# Altium schematic sheet BlinkBoard_micro_v1.SchDoc
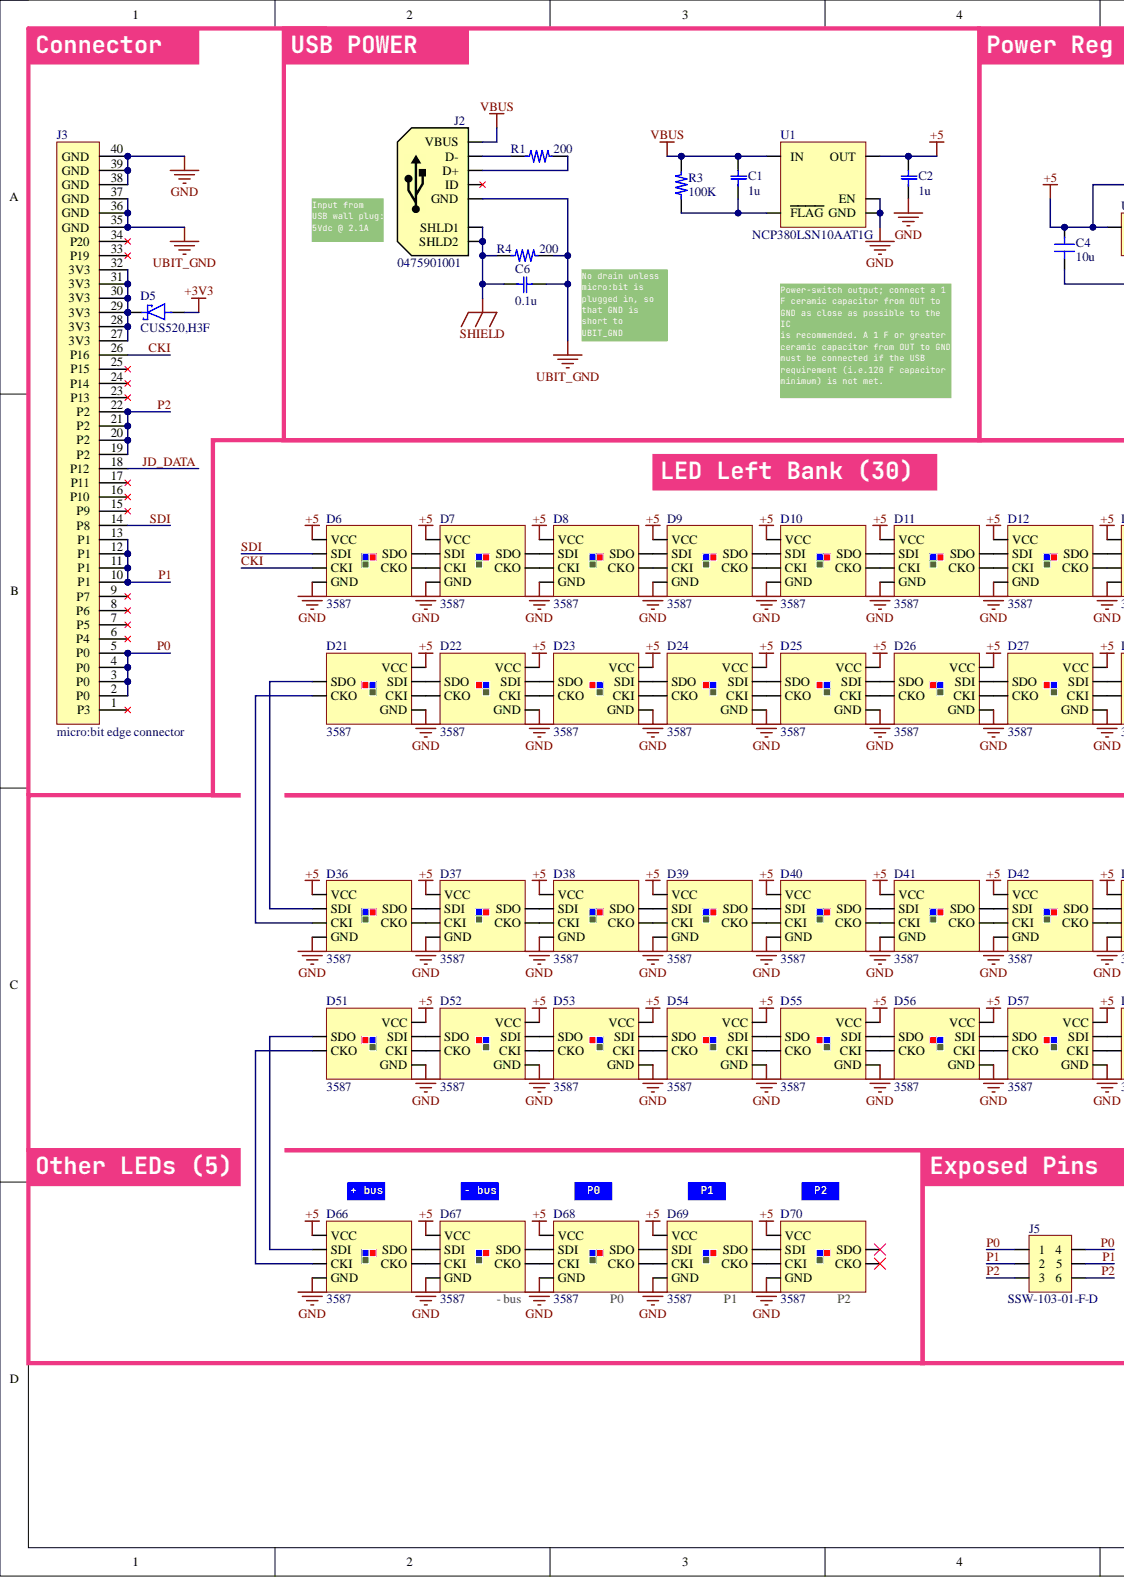
[diagram p0: BlinkBoard_micro_v1.SchDoc, left]
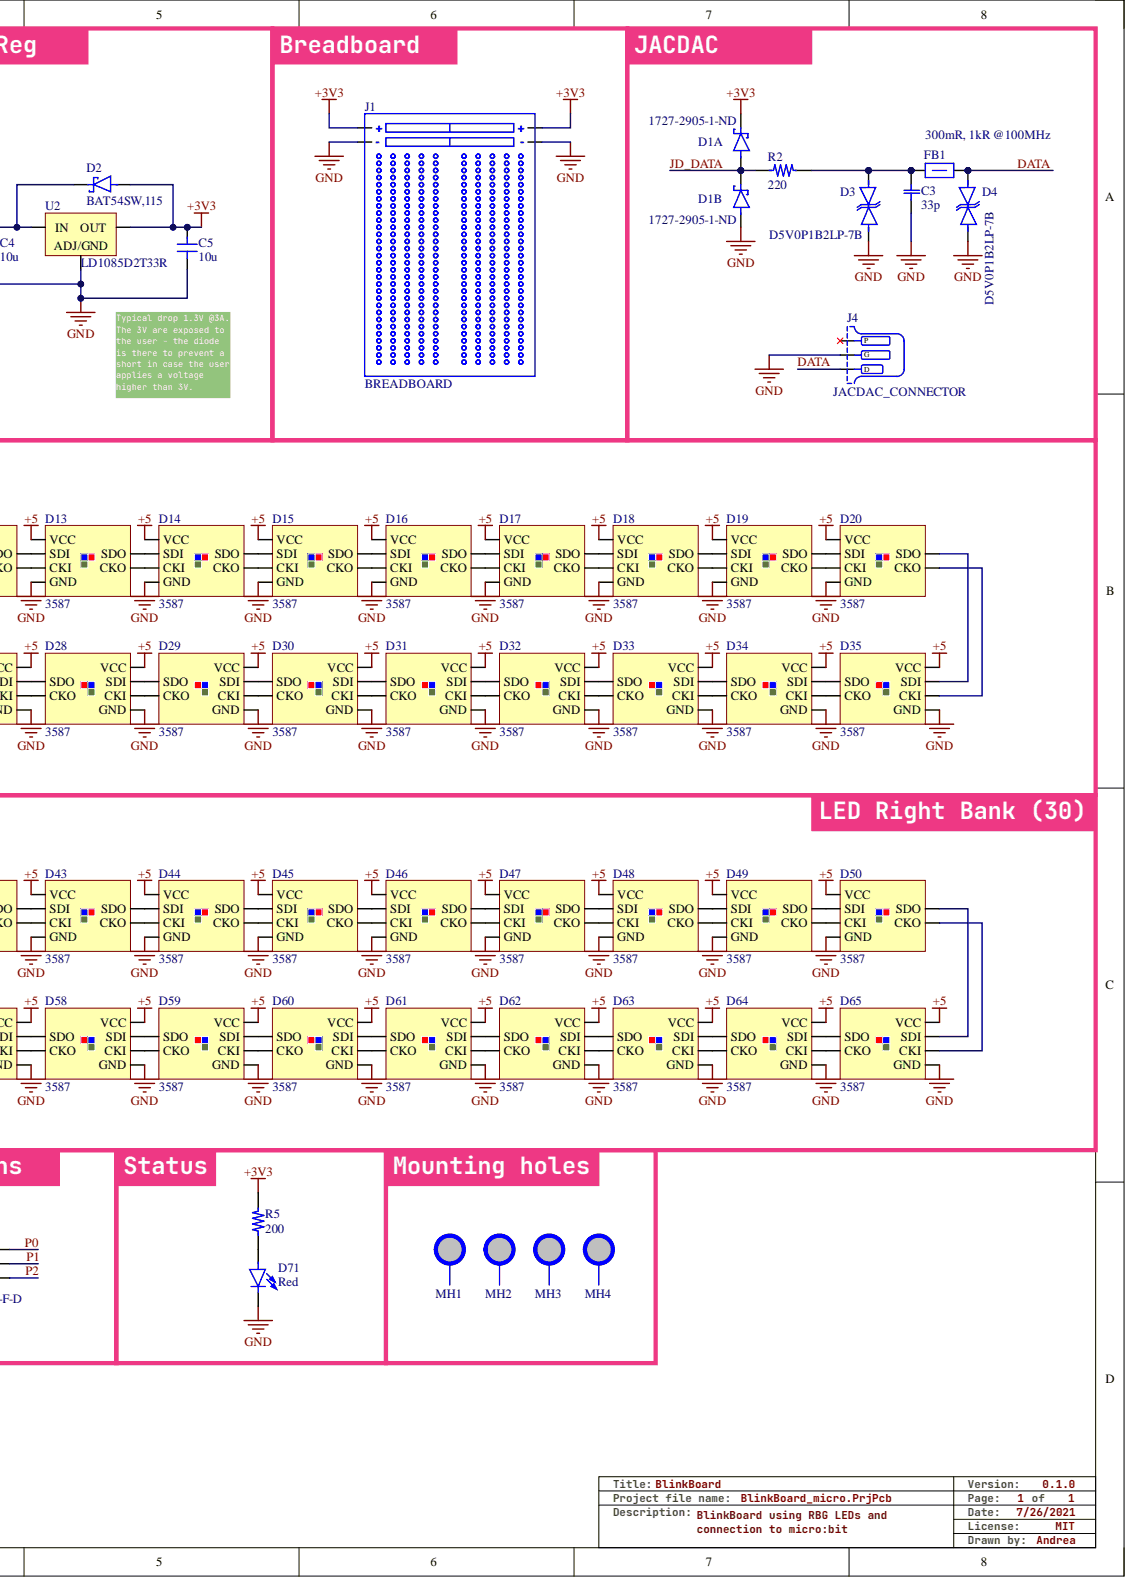
[diagram p1: BlinkBoard_micro_v1.SchDoc, right]

## Parts
C1  1u
C2  1u
C3  33p
C4  10u  [C0805]
C5  10u  [C0805]
C6  0.1u  [C0603]
D1  1727-2905-1-ND  (parts A,B)
D2  BAT54SW,115
D3  D5V0P1B2LP-7B
D4  D5V0P1B2LP-7B
D5  CUS520,H3F
D6  3587  [APA102-2020]
D7  3587  [APA102-2020]
D8  3587  [APA102-2020]
D9  3587  [APA102-2020]
D10  3587  [APA102-2020]
D11  3587  [APA102-2020]
D12  3587  [APA102-2020]
D13  3587  [APA102-2020]
D14  3587  [APA102-2020]
D15  3587  [APA102-2020]
D16  3587  [APA102-2020]
D17  3587  [APA102-2020]
D18  3587  [APA102-2020]
D19  3587  [APA102-2020]
D20  3587  [APA102-2020]
D21  3587  [APA102-2020]
D22  3587  [APA102-2020]
D23  3587  [APA102-2020]
D24  3587  [APA102-2020]
D25  3587  [APA102-2020]
D26  3587  [APA102-2020]
D27  3587  [APA102-2020]
D28  3587  [APA102-2020]
D29  3587  [APA102-2020]
D30  3587  [APA102-2020]
D31  3587  [APA102-2020]
D32  3587  [APA102-2020]
D33  3587  [APA102-2020]
D34  3587  [APA102-2020]
D35  3587  [APA102-2020]
D36  3587  [APA102-2020]
D37  3587  [APA102-2020]
D38  3587  [APA102-2020]
D39  3587  [APA102-2020]
D40  3587  [APA102-2020]
D41  3587  [APA102-2020]
D42  3587  [APA102-2020]
D43  3587  [APA102-2020]
D44  3587  [APA102-2020]
D45  3587  [APA102-2020]
D46  3587  [APA102-2020]
D47  3587  [APA102-2020]
D48  3587  [APA102-2020]
D49  3587  [APA102-2020]
D50  3587  [APA102-2020]
D51  3587  [APA102-2020]
D52  3587  [APA102-2020]
D53  3587  [APA102-2020]
D54  3587  [APA102-2020]
D55  3587  [APA102-2020]
D56  3587  [APA102-2020]
D57  3587  [APA102-2020]
D58  3587  [APA102-2020]
D59  3587  [APA102-2020]
D60  3587  [APA102-2020]
D61  3587  [APA102-2020]
D62  3587  [APA102-2020]
D63  3587  [APA102-2020]
D64  3587  [APA102-2020]
D65  3587  [APA102-2020]
D66  3587  [APA102-2020]
D67  3587  [APA102-2020]
D68  3587  [APA102-2020]
D69  3587  [APA102-2020]
D70  3587  [APA102-2020]
D71  Red
FB1  300mR, 1kR @100MHz  Impedance: 1kR
J1  BREADBOARD
J2  0475901001  Connector Type: USB  [USB_MICRO]
J3  micro:bit edge connector
J4  JACDAC_CONNECTOR
J5  SSW-103-01-F-D
MH1  MH 3.2mm NP  [MH3.2MM]  (value not drawn)  (no BOM)
MH2  MH 3.2mm NP  [MH3.2MM]  (value not drawn)  (no BOM)
MH3  MH 3.2mm NP  [MH3.2MM]  (value not drawn)  (no BOM)
MH4  MH 3.2mm NP  [MH3.2MM]  (value not drawn)  (no BOM)
R1  200  [RES0805]
R2  220
R3  100K
R4  200  [RES0805]
R5  200  [RES0805]
U1  NCP380LSN10AAT1G
U2  LD1085D2T33R  [D2PAK]

## Nets
+3V3: C5.2 D1.2 D2.2 D5.2 J1.1(+) J1.3(+) R5.2 U2.4
+5: C2.2 C4.2 D2.1 D6.4(VCC) D7.4(VCC) D8.4(VCC) D9.4(VCC) D10.4(VCC) D11.4(VCC) D12.4(VCC) D13.4(VCC) D14.4(VCC) D15.4(VCC) D16.4(VCC) D17.4(VCC) D18.4(VCC) D19.4(VCC) D20.4(VCC) D21.4(VCC) D22.4(VCC) D23.4(VCC) D24.4(VCC) D25.4(VCC) D26.4(VCC) D27.4(VCC) D28.4(VCC) D29.4(VCC) D30.4(VCC) D31.4(VCC) D32.4(VCC) D33.4(VCC) D34.4(VCC) D35.4(VCC) D36.4(VCC) D37.4(VCC) D38.4(VCC) D39.4(VCC) D40.4(VCC) D41.4(VCC) D42.4(VCC) D43.4(VCC) D44.4(VCC) D45.4(VCC) D46.4(VCC) D47.4(VCC) D48.4(VCC) D49.4(VCC) D50.4(VCC) D51.4(VCC) D52.4(VCC) D53.4(VCC) D54.4(VCC) D55.4(VCC) D56.4(VCC) D57.4(VCC) D58.4(VCC) D59.4(VCC) D60.4(VCC) D61.4(VCC) D62.4(VCC) D63.4(VCC) D64.4(VCC) D65.4(VCC) D66.4(VCC) D67.4(VCC) D68.4(VCC) D69.4(VCC) D70.4(VCC) U1.1(OUT) U2.3
GND: C2.1 C3.1 C4.1 C5.1 D1.1 D3.1 D4.1 D6.3(GND) D7.3(GND) D8.3(GND) D9.3(GND) D10.3(GND) D11.3(GND) D12.3(GND) D13.3(GND) D14.3(GND) D15.3(GND) D16.3(GND) D17.3(GND) D18.3(GND) D19.3(GND) D20.3(GND) D21.3(GND) D22.3(GND) D23.3(GND) D24.3(GND) D25.3(GND) D26.3(GND) D27.3(GND) D28.3(GND) D29.3(GND) D30.3(GND) D31.3(GND) D32.3(GND) D33.3(GND) D34.3(GND) D35.3(GND) D36.3(GND) D37.3(GND) D38.3(GND) D39.3(GND) D40.3(GND) D41.3(GND) D42.3(GND) D43.3(GND) D44.3(GND) D45.3(GND) D46.3(GND) D47.3(GND) D48.3(GND) D49.3(GND) D50.3(GND) D51.3(GND) D52.3(GND) D53.3(GND) D54.3(GND) D55.3(GND) D56.3(GND) D57.3(GND) D58.3(GND) D59.3(GND) D60.3(GND) D61.3(GND) D62.3(GND) D63.3(GND) D64.3(GND) D65.3(GND) D66.3(GND) D67.3(GND) D68.3(GND) D69.3(GND) D70.3(GND) D71.1 J1.2(-) J1.4(-) J3.38(GND) J3.39(GND) J3.40(GND) J4.2 U1.2(GND) U1.4(EN) U2.1
SHIELD: C6.1 J2.6(SHLD1) J2.7(SHLD2) R4.1
UBIT_GND: C6.2 J2.5(GND) J3.35(GND) J3.36(GND) J3.37(GND) R4.2
VBUS: C1.2 J2.1(VBUS) R3.2 U1.5(IN)
CKI: D6.2(CKI) J3.26(P16)
DATA: D4.2 FB1.2 J4.3
JD_DATA: D1.3 J3.18(P12) R2.1
P0: J3.2(P0) J3.3(P0) J3.4(P0) J3.5(P0) J5.1 J5.6
P1: J3.10(P1) J3.11(P1) J3.12(P1) J3.13(P1) J5.2 J5.5
P2: J3.19(P2) J3.20(P2) J3.21(P2) J3.22(P2) J5.3 J5.4
SDI: D6.1(SDI) J3.14(P8)
NetC1_1: C1.1 R3.1 U1.3(FLAG)
NetC3_2: C3.2 D3.2 FB1.1 R2.2
NetD5_1: D5.1 J3.27(3V3) J3.28(3V3) J3.29(3V3) J3.30(3V3) J3.31(3V3) J3.32(3V3)
NetD6_5: D6.5(CKO) D7.2(CKI)
NetD6_6: D6.6(SDO) D7.1(SDI)
NetD7_5: D7.5(CKO) D8.2(CKI)
NetD7_6: D7.6(SDO) D8.1(SDI)
NetD8_5: D8.5(CKO) D9.2(CKI)
NetD8_6: D8.6(SDO) D9.1(SDI)
NetD9_5: D9.5(CKO) D10.2(CKI)
NetD9_6: D9.6(SDO) D10.1(SDI)
NetD10_5: D10.5(CKO) D11.2(CKI)
NetD10_6: D10.6(SDO) D11.1(SDI)
NetD11_5: D11.5(CKO) D12.2(CKI)
NetD11_6: D11.6(SDO) D12.1(SDI)
NetD12_5: D12.5(CKO) D13.2(CKI)
NetD12_6: D12.6(SDO) D13.1(SDI)
NetD13_5: D13.5(CKO) D14.2(CKI)
NetD13_6: D13.6(SDO) D14.1(SDI)
NetD14_5: D14.5(CKO) D15.2(CKI)
NetD14_6: D14.6(SDO) D15.1(SDI)
NetD15_5: D15.5(CKO) D16.2(CKI)
NetD15_6: D15.6(SDO) D16.1(SDI)
NetD16_5: D16.5(CKO) D17.2(CKI)
NetD16_6: D16.6(SDO) D17.1(SDI)
NetD17_5: D17.5(CKO) D18.2(CKI)
NetD17_6: D17.6(SDO) D18.1(SDI)
NetD18_5: D18.5(CKO) D19.2(CKI)
NetD18_6: D18.6(SDO) D19.1(SDI)
NetD19_5: D19.5(CKO) D20.2(CKI)
NetD19_6: D19.6(SDO) D20.1(SDI)
NetD20_5: D20.5(CKO) D35.2(CKI)
NetD20_6: D20.6(SDO) D35.1(SDI)
NetD21_1: D21.1(SDI) D22.6(SDO)
NetD21_2: D21.2(CKI) D22.5(CKO)
NetD21_5: D21.5(CKO) D36.2(CKI)
NetD21_6: D21.6(SDO) D36.1(SDI)
NetD22_1: D22.1(SDI) D23.6(SDO)
NetD22_2: D22.2(CKI) D23.5(CKO)
NetD23_1: D23.1(SDI) D24.6(SDO)
NetD23_2: D23.2(CKI) D24.5(CKO)
NetD24_1: D24.1(SDI) D25.6(SDO)
NetD24_2: D24.2(CKI) D25.5(CKO)
NetD25_1: D25.1(SDI) D26.6(SDO)
NetD25_2: D25.2(CKI) D26.5(CKO)
NetD26_1: D26.1(SDI) D27.6(SDO)
NetD26_2: D26.2(CKI) D27.5(CKO)
NetD27_1: D27.1(SDI) D28.6(SDO)
NetD27_2: D27.2(CKI) D28.5(CKO)
NetD28_1: D28.1(SDI) D29.6(SDO)
NetD28_2: D28.2(CKI) D29.5(CKO)
NetD29_1: D29.1(SDI) D30.6(SDO)
NetD29_2: D29.2(CKI) D30.5(CKO)
NetD30_1: D30.1(SDI) D31.6(SDO)
NetD30_2: D30.2(CKI) D31.5(CKO)
NetD31_1: D31.1(SDI) D32.6(SDO)
NetD31_2: D31.2(CKI) D32.5(CKO)
NetD32_1: D32.1(SDI) D33.6(SDO)
NetD32_2: D32.2(CKI) D33.5(CKO)
NetD33_1: D33.1(SDI) D34.6(SDO)
NetD33_2: D33.2(CKI) D34.5(CKO)
NetD34_1: D34.1(SDI) D35.6(SDO)
NetD34_2: D34.2(CKI) D35.5(CKO)
NetD36_5: D36.5(CKO) D37.2(CKI)
NetD36_6: D36.6(SDO) D37.1(SDI)
NetD37_5: D37.5(CKO) D38.2(CKI)
NetD37_6: D37.6(SDO) D38.1(SDI)
NetD38_5: D38.5(CKO) D39.2(CKI)
NetD38_6: D38.6(SDO) D39.1(SDI)
NetD39_5: D39.5(CKO) D40.2(CKI)
NetD39_6: D39.6(SDO) D40.1(SDI)
NetD40_5: D40.5(CKO) D41.2(CKI)
NetD40_6: D40.6(SDO) D41.1(SDI)
NetD41_5: D41.5(CKO) D42.2(CKI)
NetD41_6: D41.6(SDO) D42.1(SDI)
NetD42_5: D42.5(CKO) D43.2(CKI)
NetD42_6: D42.6(SDO) D43.1(SDI)
NetD43_5: D43.5(CKO) D44.2(CKI)
NetD43_6: D43.6(SDO) D44.1(SDI)
NetD44_5: D44.5(CKO) D45.2(CKI)
NetD44_6: D44.6(SDO) D45.1(SDI)
NetD45_5: D45.5(CKO) D46.2(CKI)
NetD45_6: D45.6(SDO) D46.1(SDI)
NetD46_5: D46.5(CKO) D47.2(CKI)
NetD46_6: D46.6(SDO) D47.1(SDI)
NetD47_5: D47.5(CKO) D48.2(CKI)
NetD47_6: D47.6(SDO) D48.1(SDI)
NetD48_5: D48.5(CKO) D49.2(CKI)
NetD48_6: D48.6(SDO) D49.1(SDI)
NetD49_5: D49.5(CKO) D50.2(CKI)
NetD49_6: D49.6(SDO) D50.1(SDI)
NetD50_5: D50.5(CKO) D65.2(CKI)
NetD50_6: D50.6(SDO) D65.1(SDI)
NetD51_1: D51.1(SDI) D52.6(SDO)
NetD51_2: D51.2(CKI) D52.5(CKO)
NetD51_5: D51.5(CKO) D66.2(CKI)
NetD51_6: D51.6(SDO) D66.1(SDI)
NetD52_1: D52.1(SDI) D53.6(SDO)
NetD52_2: D52.2(CKI) D53.5(CKO)
NetD53_1: D53.1(SDI) D54.6(SDO)
NetD53_2: D53.2(CKI) D54.5(CKO)
NetD54_1: D54.1(SDI) D55.6(SDO)
NetD54_2: D54.2(CKI) D55.5(CKO)
NetD55_1: D55.1(SDI) D56.6(SDO)
NetD55_2: D55.2(CKI) D56.5(CKO)
NetD56_1: D56.1(SDI) D57.6(SDO)
NetD56_2: D56.2(CKI) D57.5(CKO)
NetD57_1: D57.1(SDI) D58.6(SDO)
NetD57_2: D57.2(CKI) D58.5(CKO)
NetD58_1: D58.1(SDI) D59.6(SDO)
NetD58_2: D58.2(CKI) D59.5(CKO)
NetD59_1: D59.1(SDI) D60.6(SDO)
NetD59_2: D59.2(CKI) D60.5(CKO)
NetD60_1: D60.1(SDI) D61.6(SDO)
NetD60_2: D60.2(CKI) D61.5(CKO)
NetD61_1: D61.1(SDI) D62.6(SDO)
NetD61_2: D61.2(CKI) D62.5(CKO)
NetD62_1: D62.1(SDI) D63.6(SDO)
NetD62_2: D62.2(CKI) D63.5(CKO)
NetD63_1: D63.1(SDI) D64.6(SDO)
NetD63_2: D63.2(CKI) D64.5(CKO)
NetD64_1: D64.1(SDI) D65.6(SDO)
NetD64_2: D64.2(CKI) D65.5(CKO)
NetD66_5: D66.5(CKO) D67.2(CKI)
NetD66_6: D66.6(SDO) D67.1(SDI)
NetD67_5: D67.5(CKO) D68.2(CKI)
NetD67_6: D67.6(SDO) D68.1(SDI)
NetD68_5: D68.5(CKO) D69.2(CKI)
NetD68_6: D68.6(SDO) D69.1(SDI)
NetD69_5: D69.5(CKO) D70.2(CKI)
NetD69_6: D69.6(SDO) D70.1(SDI)
NetD71_2: D71.2 R5.1
NetJ2_2: J2.2(D-) R1.1
NetJ2_3: J2.3(D+) R1.2

Not connected: D70 5(CKO) 6(SDO); J2 4(ID); J3 1(P3) 6(P4) 7(P5) 8(P6) 9(P7) 15(P9) 16(P10) 17(P11) 23(P13) 24(P14) 25(P15) 33(P19) 34(P20); J4 1

## Library data of the parts
C1 C2 = C0805_1u: Surface Mount Ceramic Multilayer Capacitor 1uF -20% to 80% Y5V 25V 0805 Paper T/R; Manufacturer: Yageo; Manufacturer Part Number: CC0805ZRY5V8BB105; Capacitance: 1uF; Case Code (Imperial): 0805; Case Code (Metric): 2012; Case/Package: 0805; Category: Ceramic Capacitors; Component Kind: Standard; ComponentLink1Description: https://datasheet.ciiva.com/144/mlccorderingcode-144703.pdf?src-supplier=Digi-Key; ComponentLink1URL: https://datasheet.ciiva.com/144/mlccorderingcode-144703.pdf?src-supplier=Digi-Key; ComponentLink2Description: https://datasheet.ciiva.com/26863/getdatasheetpartid-419810-26863011.pdf?src-supplier=Verical; ComponentLink2URL: https://datasheet.ciiva.com/26863/getdatasheetpartid-419810-26863011.pdf?src-supplier=Verical; ComponentLink3Description: https://datasheet.ciiva.com/144/mlccorderingcode-144704.pdf?src-supplier=Digi-Key; ComponentLink3URL: https://datasheet.ciiva.com/144/mlccorderingcode-144704.pdf?src-supplier=Digi-Key; ComponentLink4Description: https://datasheet.ciiva.com/144/mlccorderingcode-144705.pdf?src-supplier=Digi-Key; ComponentLink4URL: https://datasheet.ciiva.com/144/mlccorderingcode-144705.pdf?src-supplier=Digi-Key; ComponentLink5Description: https://datasheet.ciiva.com/13208/upy-gp-np0-16v-to-50v-8-13208251.pdf?src-supplier=Mouser; ComponentLink5URL: https://datasheet.ciiva.com/13208/upy-gp-np0-16v-to-50v-8-13208251.pdf?src-supplier=Mouser; ComponentLink6Description: https://datasheet.ciiva.com/28450/1132289-28450860.pdf?src-supplier=Element14; ComponentLink6URL: https://datasheet.ciiva.com/28450/1132289-28450860.pdf?src-supplier=Element14; Depth: 1.25mm; Dielectric: Y5V; Halogen Free: Halogen Free; Height: 0.85mm; Lead Free: Lead Free; Length: 2mm; Max Operating Temperature: 85°C; Min Operating Temperature: -30°C; Mount: Surface Mount; Package Quantity: 1; Packaging: Tape and Reel; Pricing 1: 1=0.14000, 10=0.10200, 50=0.05820, 100=0.04820, 500=0.03378, 1000=0.02796 (USD); Radiation Hardening: No; REACH SVHC: No SVHC; Resistor Type: Ceramic; RoHS: Yes; RoHS Compliant: Yes; Series: CC; Stock 1: 285953; Supplier 1: Digi-Key; Supplier Part Number 1: 311-1358-1-ND; Termination: SMD/SMT; Thickness: 0.033inch; Tolerance: 80%; Voltage Rating: 25V; Voltage Rating (DC): 25V; Weight: 0.000194oz; Width: 0.049inch; Library Name: BlinkBoard_micro.SchLib
C3 = C0805_33p: Cap Ceramic 33pF 50V C0G 5% SMD 0805 125C Paper T/R; Manufacturer: Yageo; Manufacturer Part Number: CC0805JRNPO9BN330; Capacitance: 33pF; Case Code (Imperial): 0805; Case Code (Metric): 2012; Case/Package: 0805; Category: Ceramic Capacitors; Component Kind: Standard; ComponentLink1Description: https://datasheet.ciiva.com/144/mlccorderingcode-144778.pdf?src-supplier=Digi-Key; ComponentLink1URL: https://datasheet.ciiva.com/144/mlccorderingcode-144778.pdf?src-supplier=Digi-Key; ComponentLink2Description: https://datasheet.ciiva.com/144/mlccorderingcode-144779.pdf?src-supplier=Digi-Key; ComponentLink2URL: https://datasheet.ciiva.com/144/mlccorderingcode-144779.pdf?src-supplier=Digi-Key; ComponentLink3Description: https://datasheet.ciiva.com/144/mlccorderingcode-144780.pdf?src-supplier=Digi-Key; ComponentLink3URL: https://datasheet.ciiva.com/144/mlccorderingcode-144780.pdf?src-supplier=Digi-Key; ComponentLink4Description: https://datasheet.ciiva.com/11464/upy-gp-np0-16v-to-50v-8-11464747.pdf?src-supplier=Avnet; ComponentLink4URL: https://datasheet.ciiva.com/11464/upy-gp-np0-16v-to-50v-8-11464747.pdf?src-supplier=Avnet; ComponentLink5Description: https://datasheet.ciiva.com/8033/1443161-8033942.pdf?src-supplier=Element14; ComponentLink5URL: https://datasheet.ciiva.com/8033/1443161-8033942.pdf?src-supplier=Element14; ComponentLink6Description: https://datasheet.ciiva.com/8033/1443161-8033944.pdf?src-supplier=Element14; ComponentLink6URL: https://datasheet.ciiva.com/8033/1443161-8033944.pdf?src-supplier=Element14; ComponentLink7Description: https://datasheet.ciiva.com/16625/upy-gp-np0-16v-to-50v-8-16625541.pdf?src-supplier=Avnet+Europe; ComponentLink7URL: https://datasheet.ciiva.com/16625/upy-gp-np0-16v-to-50v-8-16625541.pdf?src-supplier=Avnet+Europe; ComponentLink8Description: https://datasheet.ciiva.com/29241/445349-da-01-en-kerko-chip-0805-np0-33pf-5proz-50v-g-29241975.pdf?src-supplier=Conrad; ComponentLink8URL: https://datasheet.ciiva.com/29241/445349-da-01-en-kerko-chip-0805-np0-33pf-5proz-50v-g-29241975.pdf?src-supplier=Conrad; Depth: 1.25mm; Dielectric: C0G; Dielectric Material: Ceramic; Height: 0.6mm; Lead Free: Lead Free; Length: 2mm; Material: Ceramic; Max Operating Temperature: 125°C; Min Operating Temperature: -55°C; Mount: Surface Mount; Package Quantity: 1; Packaging: Tape and Reel; Pricing 1: 1=0.11000, 10=0.07800, 50=0.04280, 100=0.03490, 500=0.02492, 1000=0.01958 (USD); Radiation Hardening: No; REACH SVHC: Unknown; Resistor Type: Ceramic; RoHS: Yes; RoHS Compliant: Yes; Series: CC; Stock 1: 41012; Supplier 1: Digi-Key; Supplier Part Number 1: 311-1105-1-ND; Termination: SMD/SMT; Thickness: 0.024inch; Tolerance: 5%; Voltage: 50V; Voltage Rating: 50V; Voltage Rating (DC): 50V; Width: 1.25mm; Library Name: BlinkBoard_micro.SchLib
C4 C5 = C805_10u: Cap Ceramic 10uF 10Vdc X5R 10% SMD 0805 85°C Paper T/R; Manufacturer: Yageo; Manufacturer Part Number: CC0805KPX5R6BB106; Component Kind: Standard; ComponentLink1Description: http://datasheet.octopart.com/CC0805KPX5R6BB106-Yageo-datasheet-86397281.pdf; ComponentLink1URL: http://datasheet.octopart.com/CC0805KPX5R6BB106-Yageo-datasheet-86397281.pdf; Pricing 1: 1=0.21000, 10=0.14300, 50=0.08560, 100=0.07240, 500=0.04952, 1000=0.04380, 2500=0.04190, 5000=0.03809 (USD); RoHS Compliant: Yes; Stock 1: 54858; Supplier 1: Digi-Key; Supplier Part Number 1: 13-CC0805KPX5R6BB106CT-ND; Library Name: BlinkBoard_micro.SchLib
C6 = C0603_100n: CAP CER 0.1UF 50V X7R 0603; Manufacturer: Yageo; Manufacturer Part Number: CC0603KPX7R9BB104; Capacitance: 100nF; Tolerance: 10%; Case Code (Imperial): 0603; Case Code (Metric): 1608; Case/Package: 0603; Category: Ceramic Capacitors; Component Kind: Standard; ComponentLink1Description: https://datasheet.ciiva.com/26829/getdatasheetpartid-653026-26829414.pdf?src-supplier=Verical; ComponentLink1URL: https://datasheet.ciiva.com/26829/getdatasheetpartid-653026-26829414.pdf?src-supplier=Verical; Depth: 0.8mm; Dielectric: X7R; Halogen Free: Halogen Free; Height: 0.8mm; Lead Free: Lead Free; Length: 1.6mm; Max Operating Temperature: 125°C; Min Operating Temperature: -55°C; Mount: Surface Mount; Number of Pins: 2; Packaging: Tape and Reel; Pricing 1: 1=0.10000, 10=0.05900, 50=0.03240, 100=0.02640, 500=0.01886, 1000=0.01482, 2500=0.01348, 5000=0.01240 (USD); Radiation Hardening: No; Resistor Type: Ceramic; RoHS: Yes; RoHS Compliant: Yes; Series: CC; Stock 1: 200439; Supplier 1: Digi-Key; Supplier Part Number 1: 311-3567-6-ND; Termination: SMD/SMT; Thickness: 0.035inch; Voltage Rating: 50V; Voltage Rating (DC): 50V; Weight: 7.1E-05oz; Width: 0.031inch; Library Name: BlinkBoard_micro.SchLib
D1 = BAT54SW: Rectifier Diode Schottky 0.2A 5ns Automotive 3-Pin SC-70 T/R; Manufacturer: Nexperia; Manufacturer Part Number: BAT54SW,115; Category: Rectifier Diodes; Component Kind: Standard; ComponentLink1Description: http://www.farnell.com/datasheets/1679676.pdf?src-supplier=Farnell; ComponentLink1URL: http://www.farnell.com/datasheets/1679676.pdf?src-supplier=Farnell; ComponentLink2Description: https://assets.nexperia.com/documents/data-sheet/BAT54W_SER.pdf?src-supplier=Digi-Key; ComponentLink2URL: https://assets.nexperia.com/documents/data-sheet/BAT54W_SER.pdf?src-supplier=Digi-Key; Pricing 1: 1=0.23000, 10=0.20900, 100=0.11400, 500=0.07018, 1000=0.04785 (USD); RoHS: Yes; Stock 1: 166801; Supplier 1: Digi-Key; Supplier Part Number 1: 1727-2905-1-ND; Library Name: BlinkBoard_micro.SchLib
D2 = SCHOTTKY_RECTIFIER: Rectifier Diode Schottky 0.2A 5ns Automotive 3-Pin SC-70 T/R; Manufacturer: Nexperia; Category: Rectifier Diodes; Component Kind: Standard; ComponentLink1Description: http://www.farnell.com/datasheets/1679676.pdf?src-supplier=Farnell; ComponentLink1URL: http://www.farnell.com/datasheets/1679676.pdf?src-supplier=Farnell; ComponentLink2Description: https://assets.nexperia.com/documents/data-sheet/BAT54W_SER.pdf?src-supplier=Digi-Key; ComponentLink2URL: https://assets.nexperia.com/documents/data-sheet/BAT54W_SER.pdf?src-supplier=Digi-Key; Manufacturer Part Number: BAT54SW,115; Pricing 1: 1=0.23000, 10=0.20900, 100=0.11400, 500=0.07018, 1000=0.04785 (USD); RoHS: Yes; Stock 1: 166801; Supplier 1: Digi-Key; Supplier Part Number 1: 1727-2905-1-ND; Library Name: BlinkBoard_micro.SchLib
D3 D4 = ZENER: TVS DIODE 5.5V 13V DFN1006-2; Manufacturer: Diodes; Breakdown Voltage: 7V; Direction: Bidirectional; Element Configuration: Single; ESD Protection: Yes; Max Power Dissipation: 250mW; Max Reverse Leakage Current: 100nA; Max Surge Current: 4A; Min Breakdown Voltage: 6V; Number of Bidirectional Channels: 1; Power Line Protection: No; Case/Package: DFN; Category: TVS Diodes; Clamping Voltage: 13V; Component Kind: Standard; ComponentLink1Description: http://www.mouser.com/ds/2/115/D5V0P1B2LP-368667.pdf?src-supplier=Mouser; ComponentLink1URL: http://www.mouser.com/ds/2/115/D5V0P1B2LP-368667.pdf?src-supplier=Mouser; ComponentLink2Description: http://www.diodes.com/_files/datasheets/D5V0P1B2LP.pdf?src-supplier=Avnet; ComponentLink2URL: http://www.diodes.com/_files/datasheets/D5V0P1B2LP.pdf?src-supplier=Avnet; ComponentLink3Description: https://www.diodes.com/assets/Datasheets/D5V0P1B2LP.pdf?src-supplier=Digi-Key; ComponentLink3URL: https://www.diodes.com/assets/Datasheets/D5V0P1B2LP.pdf?src-supplier=Digi-Key; Contact Plating: Gold; Manufacturer Part Number: D5V0P1B2LP-7B; Max Operating Temperature: 150°C; Min Operating Temperature: -65°C; Mount: Surface Mount; Number of Pins: 2; Package Quantity: 10000; Packaging: Tape and Reel; Peak Pulse Current: 4A; Peak Pulse Power: 250mW; Polarity: Bidirectional; Pricing 1: 1=0.28000, 10=0.22700, 100=0.12040, 500=0.07920, 1000=0.05386, 2000=0.04858, 5000=0.04224 (USD); Resistor Type: Zener; Reverse Standoff Voltage: 5.5V; RoHS: Yes; RoHS Compliant: Yes; Stock 1: 24260; Supplier 1: Digi-Key; Supplier Part Number 1: D5V0P1B2LP-7BDICT-ND; Termination: SMD/SMT; Working Voltage: 5.5V; Library Name: BlinkBoard_micro.SchLib
D5 = SCHOTTKY_0.2A: Diode Small Signal Schottky Si 0.2A 2-Pin USC T/R; Manufacturer: Toshiba; Average Rectified Current: 200mA; Element Configuration: Single; Max Repetitive Reverse Voltage (Vrrm): 30V; Max Reverse Current: 5uA; Peak Non-Repetitive Surge Current: 1A; Category: Rectifier Diodes; Component Kind: Standard; ComponentLink1Description: https://toshiba.semicon-storage.com/info/docget.jsp?did=7041&prodName=CUS520&src-supplier=Digi-Key; ComponentLink1URL: https://toshiba.semicon-storage.com/info/docget.jsp?did=7041&prodName=CUS520&src-supplier=Digi-Key; ComponentLink2Description: http://toshiba.semicon-storage.com/info/docget.jsp?did=7041&prodName=CUS520&src-supplier=Avnet; ComponentLink2URL: http://toshiba.semicon-storage.com/info/docget.jsp?did=7041&prodName=CUS520&src-supplier=Avnet; ComponentLink3Description: http://toshiba.semicon-storage.com/info/lookup.jsp?pid=CUS520&amp;lang=en&src-supplier=Mouser; ComponentLink3URL: http://toshiba.semicon-storage.com/info/lookup.jsp?pid=CUS520&amp;lang=en&src-supplier=Mouser; Contact Plating: Tin,Silver; Manufacturer Part Number: CUS520,H3F; Max Operating Temperature: 100°C; Max Reverse Voltage (DC): 30V; Min Operating Temperature: -40°C; Mount: Surface Mount; Number of Pins: 2; Packaging: Tape and Reel; Pricing 1: 1=0.22000, 10=0.17700, 100=0.09400, 500=0.06188, 1000=0.04208 (USD); RoHS: No; RoHS Compliant: No; Stock 1: 16652; Supplier 1: Digi-Key; Supplier Part Number 1: CUS520H3FCT-ND; Library Name: BlinkBoard_micro.SchLib
D6-D70 = DOT_STAR_LED: DotStar Micro LEDs (APA102–2020)- Smart SMD RGB LED - 100 pack; Manufacturer: Adafruit Industries; Color: Blue,Green,Red; Interface: Serial; Number of LEDs: 100; Component Kind: Standard; ComponentLink1Description: https://media.digikey.com/pdf/Data%20Sheets/Adafruit%20PDFs/3587_Web.pdf?src-supplier=Digi-Key; ComponentLink1URL: https://media.digikey.com/pdf/Data%20Sheets/Adafruit%20PDFs/3587_Web.pdf?src-supplier=Digi-Key; Length: 2mm; Manufacturer Part Number: 3587; Mount: Surface Mount; Pricing 1: 1=29.95000 (USD); RoHS: No; Stock 1: 113; Supplier 1: Digi-Key; Supplier Part Number 1: 1528-2392-ND; Width: 2mm; Library Name: BlinkBoard_micro.SchLib
D71 = LED0805: WURTH ELEKTRONIK - 150060RS75000 - LED, Red, 625 nm, 2 V, 30 mA, 250 mcd RoHS Compliant: Yes (150060RS75000); Manufacturer: Wurth Electronics; Dominant Wavelength: 625nm; Forward Current: 30mA; Forward Voltage: 2V; Lens Style: Clear,Rectangular; Luminous Intensity: 250mcd; Test Current: 20mA; Viewing Angle: 140°; Case Code (Imperial): 0603; Case Code (Metric): 1608; Case/Package: 0603; Category: LEDs; Color: Red; Component Kind: Standard; ComponentLink1Description: https://datasheet.ciiva.com/29563/150060rs75000-29563040.pdf?src-supplier=Digi-Key; ComponentLink1URL: https://datasheet.ciiva.com/29563/150060rs75000-29563040.pdf?src-supplier=Digi-Key; ComponentLink2Description: https://datasheet.ciiva.com/29279/759428-da-01-en-chipled-0603-w-kl-rot-150060rs75000-29279196.pdf?src-supplier=Conrad; ComponentLink2URL: https://datasheet.ciiva.com/29279/759428-da-01-en-chipled-0603-w-kl-rot-150060rs75000-29279196.pdf?src-supplier=Conrad; Height: 0.7mm; Length: 1.6mm; Manufacturer Part Number: 150060RS75000; Max Operating Temperature: 85°C; Min Operating Temperature: -40°C; Mount: Surface Mount; Package Quantity: 1; Packaging: Cut Tape; Pricing 1: 1=0.14000, 50=0.13300, 100=0.12000, 500=0.11400, 1000=0.09900 (USD); REACH SVHC: Unknown; RoHS: Yes; RoHS Compliant: Yes; Stock 1: 200517; Supplier 1: Digi-Key; Supplier Part Number 1: 732-4978-1-ND; Wavelength: 625nm; Width: 0.8mm; Library Name: BlinkBoard_micro.SchLib
FB1 = BEAD: Ferrite Beads Multi-Layer Chip Bead 1KOhm 25% 100MHz 600mA 0603 T/R; Manufacturer: Wurth Electronics; Manufacturer Part Number: 742792662; Number of Lines: 1; Test Frequency: 120MHz; Case Code (Imperial): 0603; Case Code (Metric): 1608; Case/Package: SMD/SMT; Category: Ferrite Beads and Chips; Component Kind: Standard; ComponentLink1Description: https://datasheet.ciiva.com/29364/742792662-29364469.pdf?src-supplier=Digi-Key; ComponentLink1URL: https://datasheet.ciiva.com/29364/742792662-29364469.pdf?src-supplier=Digi-Key; ComponentLink2Description: https://datasheet.ciiva.com/30489/0900766b812a6756-30489145.pdf?src-supplier=RSComponents; ComponentLink2URL: https://datasheet.ciiva.com/30489/0900766b812a6756-30489145.pdf?src-supplier=RSComponents; ComponentLink3Description: https://datasheet.ciiva.com/29364/742792662-29364471.pdf?src-supplier=Digi-Key; ComponentLink3URL: https://datasheet.ciiva.com/29364/742792662-29364471.pdf?src-supplier=Digi-Key; ComponentLink4Description: https://datasheet.ciiva.com/29364/742792662-29364473.pdf?src-supplier=Digi-Key; ComponentLink4URL: https://datasheet.ciiva.com/29364/742792662-29364473.pdf?src-supplier=Digi-Key; DC Resistance (DCR): 300mR; Depth: 0.8mm; Height: 0.8mm; Lead Free: Lead Free; Length: 1.6mm; Material: Ferrite; Max Current Rating: 600mA; Max DC Current: 600mA; Max Operating Temperature: 125°C; Min Operating Temperature: -55°C; Mount: Surface Mount; Package Quantity: 4000; Packaging: Tape and Reel; Pricing 1: 1=0.17000, 50=0.16800, 100=0.15500, 500=0.14800, 1000=0.14100, 2000=0.13400 (USD); RoHS: Yes; RoHS Compliant: Yes; Stock 1: 259725; Supplier 1: Digi-Key; Supplier Part Number 1: 732-4670-1-ND; Termination: SMD/SMT; Tolerance: 25%; Weight: 7.1E-05oz; Width: 0.031inch; Library Name: BlinkBoard_micro.SchLib
J2 = USB_MICRO: USB - micro AB  Receptacle Connector 5 Position Surface Mount, Right Angle, Horizontal; Manufacturer: Molex; Max Voltage Rating (AC): 30V; Number of Contacts: 5; Number of Ports: 1; Pitch: 0.65mm; Category: USB Connectors; Component Kind: Standard; ComponentLink1Description: https://datasheet.ciiva.com/29660/475901001-sd-29660111.pdf?src-supplier=Digi-Key; ComponentLink1URL: https://datasheet.ciiva.com/29660/475901001-sd-29660111.pdf?src-supplier=Digi-Key; Contact Material: Copper Alloy; Depth: 6.1mm; Features: Shielded; Gender: Receptacle; Housing Material: Thermoplastic; Length: 8.65mm; Manufacturer Part Number: 0475901001; Max Operating Temperature: 85°C; Min Operating Temperature: -30°C; Mount: Surface Mount,Horizontal; Number of Terminals/Pins: 5; Orientation: Right Angle; Package Quantity: 1500; Packaging: Tape and Reel; Pricing 1: 1=0.97000, 10=0.79900, 100=0.66600 (USD); Radiation Hardening: No; RoHS: Yes; RoHS Compliant: Yes; Stock 1: 6607; Supplier 1: Digi-Key; Supplier Part Number 1: WM14336CT-ND; Termination: Solder; Library Name: BlinkBoard_micro.SchLib
J3 = EdgeConnector: 3887; Manufacturer: Adafruit Industries; Component Kind: Standard; Manufacturer Part Number: 3887; Pricing 1: 1=2.95000 (USD); RoHS: No; Stock 1: 1; Supplier 1: Digi-Key; Supplier Part Number 1: 1528-2644-ND; Library Name: BlinkBoard_micro.SchLib
J5 = HDR_6_2.54: SAMTEC - SSW-103-01-F-D - CONNECTOR, RECEPTACLE, 6 CONTACTS, 2 ROWS, 2.54 MM; Contact Resistance: 10mR; Gender: Female; Mating Cycles: 100; Max Current Rating: 7.6A; Max Voltage Rating (AC): 550V; Number of Contacts: 6; Pitch: 2.54mm; Category: Headers and Wire Housings; Color: Black; Component Kind: Standard; ComponentLink1Description: http://www.farnell.com/datasheets/2550265.pdf?src-supplier=Farnell; ComponentLink1URL: http://www.farnell.com/datasheets/2550265.pdf?src-supplier=Farnell; ComponentLink2Description: http://components.arrow.com/part/dataview/4842126S6546715N1004?src-supplier=Arrow+Electronics; ComponentLink2URL: http://components.arrow.com/part/dataview/4842126S6546715N1004?src-supplier=Arrow+Electronics; ComponentLink3Description: http://components.arrow.com/part/dataview/0323_00788229?src-supplier=Arrow+Europe; ComponentLink3URL: http://components.arrow.com/part/dataview/0323_00788229?src-supplier=Arrow+Europe; ComponentLink4Description: ftp://ftp.samtec.com/webfiles/PDF/SSQ_TH.PDF?src-supplier=Avnet; ComponentLink4URL: ftp://ftp.samtec.com/webfiles/PDF/SSQ_TH.PDF?src-supplier=Avnet; ComponentLink5Description: http://suddendocs.samtec.com/catalog_english/ssw_th.pdf?src-supplier=Digi-Key; ComponentLink5URL: http://suddendocs.samtec.com/catalog_english/ssw_th.pdf?src-supplier=Digi-Key; ComponentLink6Description: ftp://ftp.samtec.com/webfiles/PDF/SSQ_TH.PDF?src-supplier=Avnet+Europe; ComponentLink6URL: ftp://ftp.samtec.com/webfiles/PDF/SSQ_TH.PDF?src-supplier=Avnet+Europe; ComponentLink7Description: http://www.farnell.com/datasheets/2550265.pdf?src-supplier=Newark; ComponentLink7URL: http://www.farnell.com/datasheets/2550265.pdf?src-supplier=Newark; Contact Material: Bronze; Contact Plating: Gold; Depth: 4.95mm; Housing Color: Black; Housing Material: Polymer; Length: 8.13mm; Manufacturer: Samtec; Manufacturer Part Number: SSW-103-01-F-D; Max Operating Temperature: 125°C; Min Operating Temperature: -55°C; Number of Rows: 2; Orientation: Vertical; Packaging: Bulk; Pricing 1: 1=1.42000, 10=1.28700, 100=1.10290, 500=0.91910, 1000=0.78780, 5000=0.69852, 10000=0.68276 (USD); Radiation Hardening: No; RoHS: Yes; RoHS Compliant: Yes; Stock 1: 1509; Supplier 1: Digi-Key; Supplier Part Number 1: SAM10016-ND; Termination: Solder; Library Name: BlinkBoard_micro.SchLib
MH1-MH4 = MHNP: Mounting hole 3.2mm non plated; Library Name: BlinkBoard_micro.SchLib
R1 R4 R5 = R0805_200: RES SMD 200 OHM 0.5% 1/8W 0805; Manufacturer: Yageo; Manufacturer Part Number: RT0805DRE07200RL; Max Power Dissipation: 125mW; Resistance: 200R; Temperature Coefficient: 50ppm/°C; Voltage Rating (DC): 150V; Case Code (Imperial): 0805; Case Code (Metric): 2012; Case/Package: 0805; Category: Chip SMD Resistors; Component Kind: Standard; ComponentLink1Description: https://datasheet.ciiva.com/2569/pyu-rt-1-to-0-05-rohs-l-5-2569311.pdf?src-supplier=Digi-Key; ComponentLink1URL: https://datasheet.ciiva.com/2569/pyu-rt-1-to-0-05-rohs-l-5-2569311.pdf?src-supplier=Digi-Key; Features: Moisture Resistant; Height: 0.024inch; Lead Free: Lead Free; Length: 0.079inch; Max Operating Temperature: 155°C; Min Operating Temperature: -55°C; Number of Terminations: 2; Package Quantity: 5000; Packaging: Tape and Reel; Pricing 1: 1=0.12000, 10=0.11000, 100=0.04220, 1000=0.01855, 2500=0.01602 (USD); Resistor Type: Thin Film; RoHS: Yes; RoHS Compliant: Yes; Series: RT; Stock 1: 730; Supplier 1: Digi-Key; Supplier Part Number 1: 311-2816-1-ND; Tolerance: 0.5%; Width: 0.049inch; Library Name: BlinkBoard_micro.SchLib
R2 = R0805_220: Res General Purpose Thick Film 0805 220 Ohm 5% 1/8W ±100ppm/°C Molded SMD Paper T/R; Manufacturer: Yageo; Manufacturer Part Number: RC0805JR-07220RL; Max Power Dissipation: 125mW; Resistance: 220R; Voltage Rating: 150V; Case Code (Imperial): 0805; Case Code (Metric): 2012; Case/Package: 0805; Category: Chip SMD Resistors; Component Kind: Standard; ComponentLink1Description: https://datasheet.ciiva.com/7941/442941-7941917.pdf?src-supplier=Element14; ComponentLink1URL: https://datasheet.ciiva.com/7941/442941-7941917.pdf?src-supplier=Element14; ComponentLink2Description: https://datasheet.ciiva.com/7941/442941-7941918.pdf?src-supplier=Element14; ComponentLink2URL: https://datasheet.ciiva.com/7941/442941-7941918.pdf?src-supplier=Element14; ComponentLink3Description: https://datasheet.ciiva.com/16914/rc0805-16914046.pdf?src-supplier=Future+Electronics; ComponentLink3URL: https://datasheet.ciiva.com/16914/rc0805-16914046.pdf?src-supplier=Future+Electronics; Construction: Rectangular; Contact Plating: Tin,Matte,Lead; Depth: 1.25mm; Features: Moisture Resistant; Lead Free: Lead Free; Length: 2mm; Max Operating Temperature: 155°C; Military Standard: Not; Min Operating Temperature: -55°C; Number of Terminations: 2; Packaging: Tape and Reel; Power Rating: 125mW; Pricing 1: 1=0.10000, 10=0.03600, 100=0.01450, 1000=0.00652, 2500=0.00566 (USD); Radiation Hardening: No; REACH SVHC: Unknown; Resistance Tolerance: 5%; Resistor Type: Thick Film; RoHS: Yes; RoHS Compliant: Yes; Series: RC; Size Code: 0805; Stock 1: 650208; Supplier 1: Digi-Key; Supplier Part Number 1: 311-220ARCT-ND; Temperature Coefficient: 100ppm/°C; Termination: SMD/SMT; Tolerance: 5%; Library Name: BlinkBoard_micro.SchLib
R3 = R0805_100K: Res Thick Film 0805 100K Ohm 5% 1/8W 100ppm/C Molded SMD SMD Paper T/R; Manufacturer: Yageo; Manufacturer Part Number: RC0805JR-07100KL; Max Power Dissipation: 125mW; Resistance: 100kR; Temperature Coefficient: 100ppm/°C; Voltage Rating: 150V; Case Code (Imperial): 0805; Case Code (Metric): 2012; Case/Package: 0805; Category: Chip SMD Resistors; Component Kind: Standard; ComponentLink1Description: https://datasheet.ciiva.com/7941/442941-7941865.pdf?src-supplier=Element14; ComponentLink1URL: https://datasheet.ciiva.com/7941/442941-7941865.pdf?src-supplier=Element14; ComponentLink2Description: https://datasheet.ciiva.com/7941/442941-7941864.pdf?src-supplier=Element14; ComponentLink2URL: https://datasheet.ciiva.com/7941/442941-7941864.pdf?src-supplier=Element14; ComponentLink3Description: https://datasheet.ciiva.com/15190/getdatasheetpartid-479267-15190029.pdf?src-supplier=Verical; ComponentLink3URL: https://datasheet.ciiva.com/15190/getdatasheetpartid-479267-15190029.pdf?src-supplier=Verical; Construction: Rectangular; Contact Plating: Tin,Matte,Lead; Depth: 1.25mm; Features: Moisture Resistant; Lead Free: Lead Free; Length: 2mm; Max Operating Temperature: 155°C; Military Standard: Not; Min Operating Temperature: -55°C; Number of Terminations: 2; Packaging: Tape and Reel; Power Rating: 125mW; Pricing 1: 1=0.10000, 10=0.03600, 100=0.01450, 1000=0.00652, 2500=0.00566 (USD); Radiation Hardening: No; REACH SVHC: Unknown; Resistance Tolerance: 5%; Resistor Type: Thick Film; RoHS: Yes; RoHS Compliant: Yes; Series: RC; Size Code: 0805; Stock 1: 349858; Supplier 1: Digi-Key; Supplier Part Number 1: 311-100KARCT-ND; Termination: SMD/SMT; Tolerance: 5%; Library Name: BlinkBoard_micro.SchLib
U1 = NCP380: REEL / OVER CURRENT PROTECTION; Manufacturer: ON Semiconductor; Current Rating: 1A; Interface: On/Off; Max Input Voltage: 5.5V; Max Power Dissipation: 325mW; Min Input Voltage: 2.5V; Number of Inputs: 2; Number of Outputs: 1; On-State Resistance: 135mR; On-state Resistance-Max: 110MR; Output Configuration: High Side; Turn-Off Delay Time: 3ms; Turn-On Delay Time: 4ms; Case/Package: TSOP; Category: USB Interface ICs; Component Kind: Standard; ComponentLink1Description: https://datasheet.ciiva.com/1939/ncp380-d-1939778.pdf?src-supplier=Digi-Key; ComponentLink1URL: https://datasheet.ciiva.com/1939/ncp380-d-1939778.pdf?src-supplier=Digi-Key; ComponentLink2Description: https://datasheet.ciiva.com/1939/ncp380-d-1939779.pdf?src-supplier=Digi-Key; ComponentLink2URL: https://datasheet.ciiva.com/1939/ncp380-d-1939779.pdf?src-supplier=Digi-Key; ComponentLink3Description: https://datasheet.ciiva.com/1939/ncp380-d-1939780.pdf?src-supplier=Digi-Key; ComponentLink3URL: https://datasheet.ciiva.com/1939/ncp380-d-1939780.pdf?src-supplier=Digi-Key; ComponentLink4Description: https://datasheet.ciiva.com/16468/ncp380-d-16468713.pdf?src-supplier=Future+Electronics; ComponentLink4URL: https://datasheet.ciiva.com/16468/ncp380-d-16468713.pdf?src-supplier=Future+Electronics; Halogen Free: Halogen Free; Height: 1mm; Lead Free: Lead Free; Length: 3mm; Manufacturer Part Number: NCP380LSN10AAT1G; Max Operating Temperature: 85°C; Max Output Current: 1A; Min Operating Temperature: -40°C; Mount: Surface Mount; Number of Pins: 5; Number of Switches: 1; Operating Supply Voltage: 5.5V; Output Current: 1A; Package Quantity: 1; Packaging: Tape and Reel; Pricing 1: 1=0.76000, 10=0.66500, 25=0.62440, 100=0.50950, 250=0.47328, 500=0.40280, 1000=0.33250 (USD); Rated Current-Max: 1A; RoHS: Yes; RoHS Compliant: Yes; Series: -; Supplier 1: Digi-Key; Supplier Part Number 1: NCP380LSN10AAT1GOSCT-ND; Width: 1.5mm; Library Name: BlinkBoard_micro.SchLib
U2 = LD1085D2T33R: Fixed LDO Voltage Regulator, 6.3V to 30V, 1.3V Dropout, 3.3Vout, 3Aout, TO-263-3; Manufacturer: STMicroelectronics; Accuracy: 1%; Input Bias Current: 5mA; Max Output Current: 3A; Max Output Voltage: 3.333V; Min Output Voltage: 3.3V; Min Supply Voltage: 4.9V; Number of Outputs: 1; Polarity: Positive; Quiescent Current: 10mA; Case/Package: TO-263; Component Kind: Standard; ComponentLink1Description: https://datasheet.ciiva.com/16375/cd00001883-16375381.pdf; ComponentLink1URL: https://datasheet.ciiva.com/16375/cd00001883-16375381.pdf; ComponentLink2Description: https://datasheet.ciiva.com/1511/1761309-1511542.pdf; ComponentLink2URL: https://datasheet.ciiva.com/1511/1761309-1511542.pdf; ComponentLink3Description: https://datasheet.ciiva.com/22694/1761309-22694841.pdf; ComponentLink3URL: https://datasheet.ciiva.com/22694/1761309-22694841.pdf; ComponentLink4Description: https://datasheet.ciiva.com/2044/cd00001883-2044187.pdf; ComponentLink4URL: https://datasheet.ciiva.com/2044/cd00001883-2044187.pdf; ComponentLink5Description: https://datasheet.ciiva.com/15111/getdatasheetpartid-65476-15111291.pdf; ComponentLink5URL: https://datasheet.ciiva.com/15111/getdatasheetpartid-65476-15111291.pdf; ComponentLink6Description: https://datasheet.ciiva.com/2044/cd00001883-2044188.pdf; ComponentLink6URL: https://datasheet.ciiva.com/2044/cd00001883-2044188.pdf; ComponentLink7Description: https://datasheet.ciiva.com/2044/cd00001883-2044189.pdf; ComponentLink7URL: https://datasheet.ciiva.com/2044/cd00001883-2044189.pdf; ComponentLink8Description: https://datasheet.ciiva.com/41439/001184676-da-01-en-ic-reg-ldo-3-3-ld1085d2t33r-to-263-3-stm-41439834.pdf; ComponentLink8URL: https://datasheet.ciiva.com/41439/001184676-da-01-en-ic-reg-ldo-3-3-ld1085d2t33r-to-263-3-stm-41439834.pdf; Contact Plating: Tin,Matte; Dropout Voltage: 1.3V; Height: 4.6mm; Lead Free: Lead Free; Length: 10.4mm; Manufacturer Part Number: LD1085D2T33R; Max Input Voltage: 30V; Max Operating Temperature: 125°C; Max Supply Voltage: 30V; Min Input Voltage: 6.3V; Min Operating Temperature: -40°C; Mount: Surface Mount; Nominal Output Voltage: 3.3V; Number of Pins: 3; Number of Regulators: 1; Output Current: 3A; Output Voltage: 3.3V; Output Voltage Accuracy: 1%; Package Quantity: 1; Packaging: Tape and Reel; Pricing 1: 1=1.46, 10=1.305, 100=1.0176, 500=0.84066 (USD); Radiation Hardening: No; REACH SVHC: No SVHC; Stock 1: 5416; Supplier 1: Digi-Key; Supplier Part Number 1: 497-1222-6-ND; Termination: SMD/SMT; Weight: 0.01lb; Width: 9.35mm; Library Name: VirtualSensors.SchLib
J1 = BREADBOARD: Component Kind: Standard; Library Name: BlinkBoard_micro.SchLib
J4 = JACDAC_CONNECTOR: Component Kind: Standard; Library Name: BlinkBoard_micro.SchLib
